# Altium schematic sheet 011_SoCSDMMC&I2C&I2S&GPIO.SchDoc(011_SoCSDMMC&I2C&I2S&GPIO)
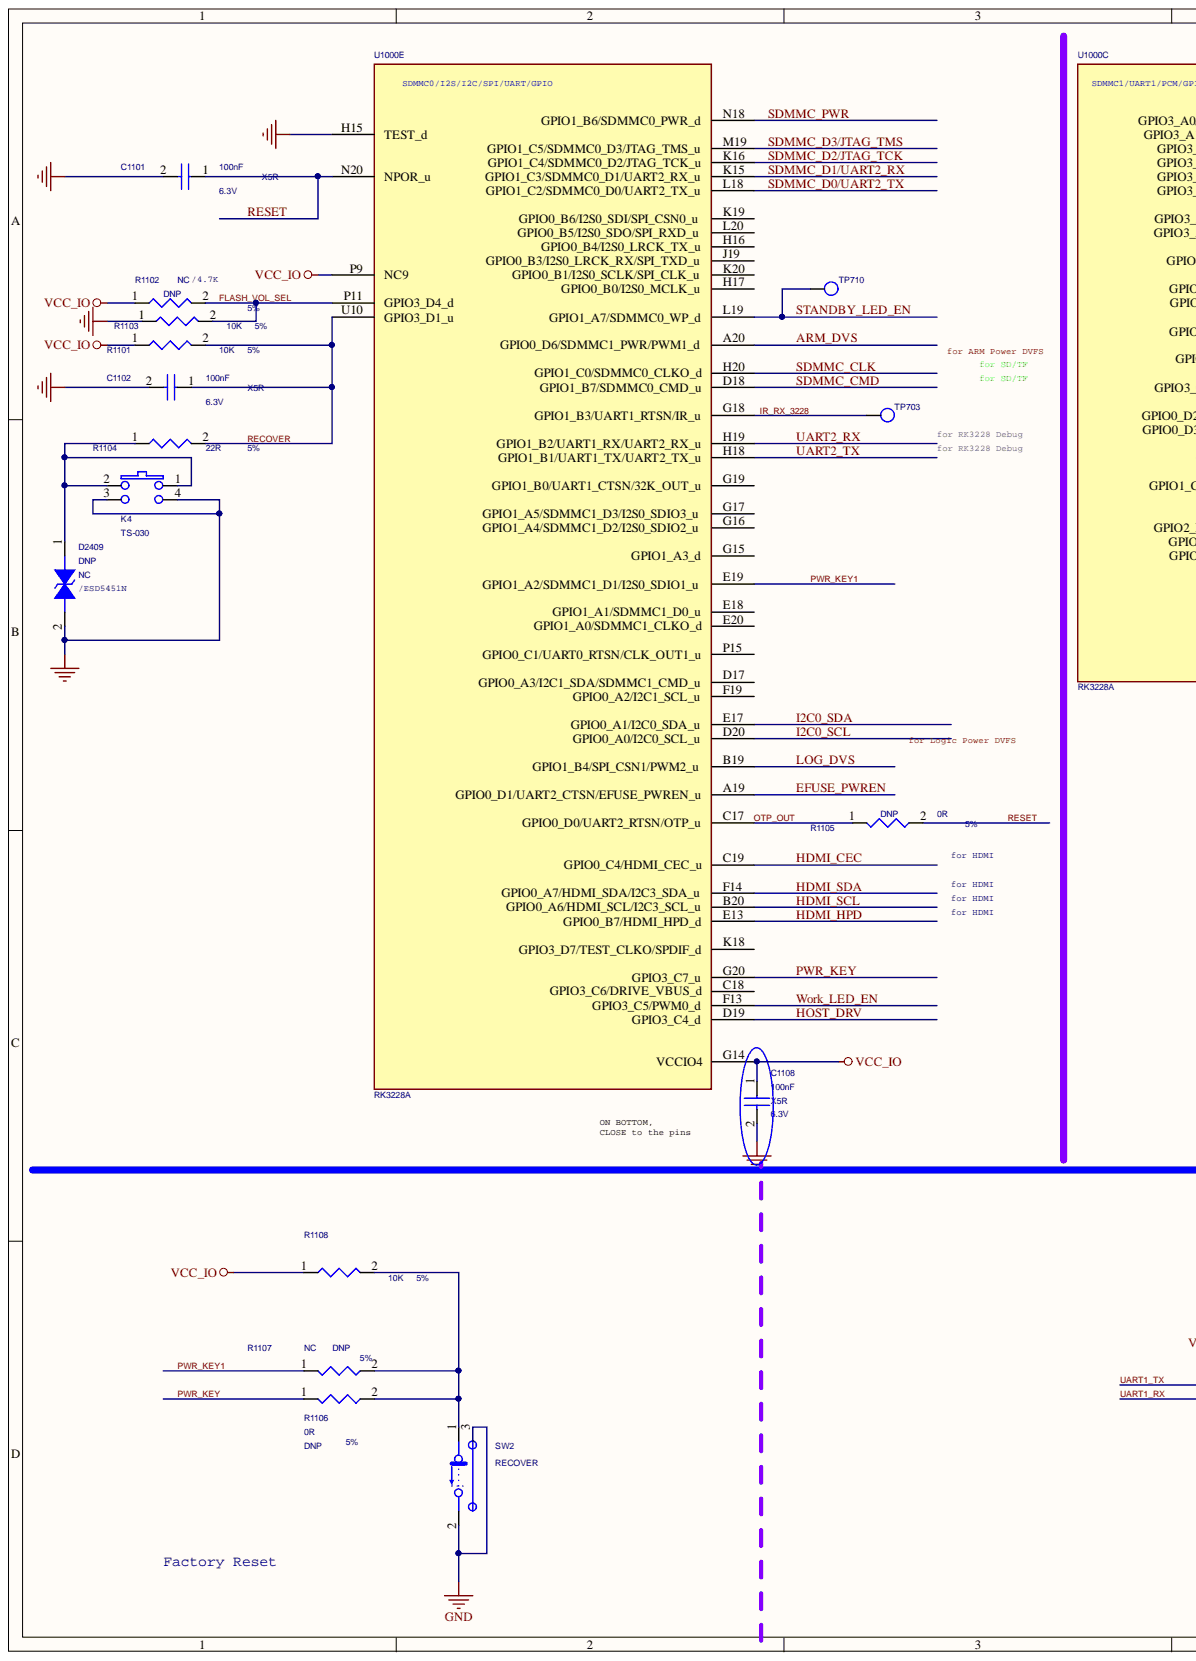
[diagram p0: 011_SoCSDMMC&I2C&I2S&GPIO.SchDoc, left]
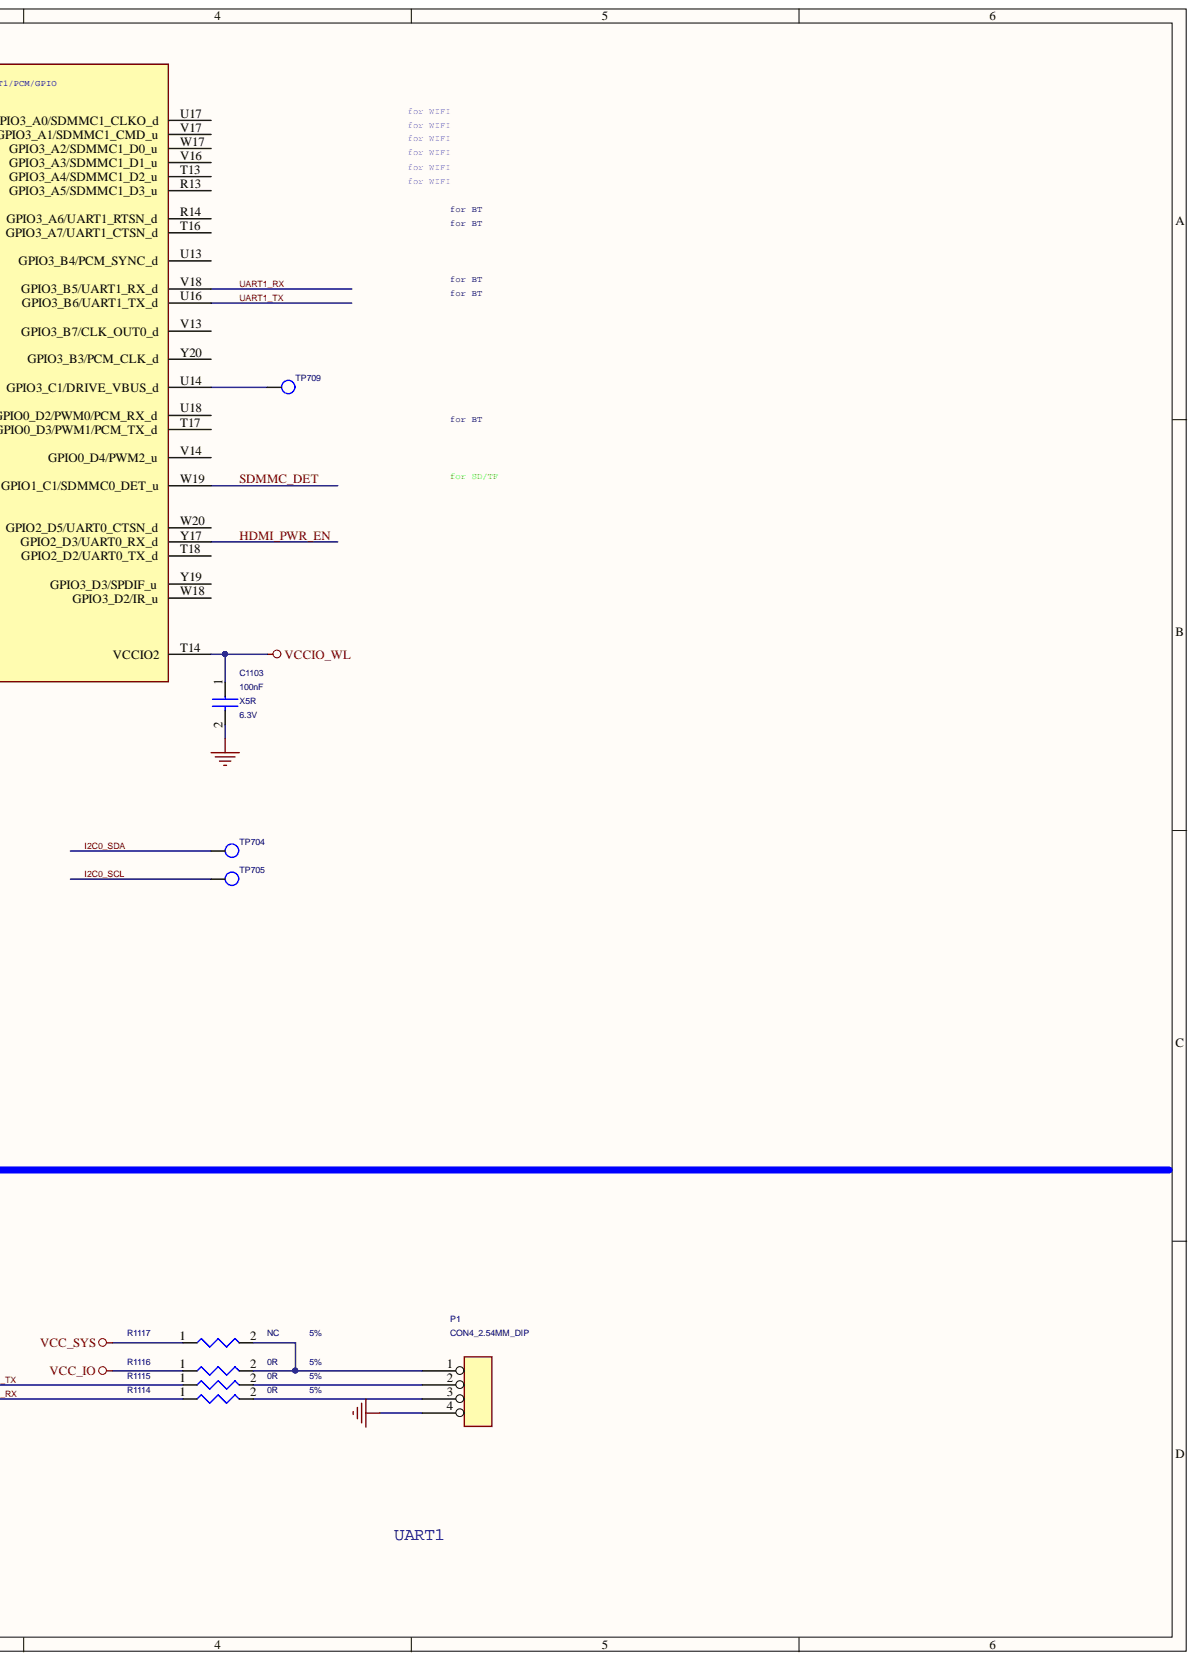
[diagram p1: 011_SoCSDMMC&I2C&I2S&GPIO.SchDoc, right]

## Parts
C1101  100nF  Voltage: 6.3V  [C0402]
C1102  100nF  Voltage: 6.3V  [C0402]
C1103  100nF  Voltage: 6.3V  Dielectric: X5R  [C0402]
C1108  100nF  Voltage: 6.3V  Dielectric: X5R  [C0402]
D2409  NC  OPTION: DNP  [ESD0402]
K4  TS-030  [SW-KFC-A04]
P1  CON4_2.54MM_DIP  [CON4_2.5MM_DIP]
R1101  10K  [R0402]
R1102  NC  option: DNP  [R0402]
R1103  10K  Tolerance: 5%  [R0402]
R1104  22R  [R0402]
R1105  0R  Option: DNP  [R0402]
R1106  0R  Tolerance: 5%  [R0402]
R1107  NC  Tolerance: 5%  Option: DNP  [R0402]
R1108  10K  [R0402]
R1114  0R  [R0402]
R1115  0R  [R0402]
R1116  0R  [R0402]
R1117  NC  [R0402]
SW2  RECOVER  [button]
TP703  TP_0.7  [TEST]  (value not drawn)
TP704  TP_0.7  [TEST]  (value not drawn)
TP705  TP_0.7  [TEST]  (value not drawn)
TP709  TP_0.7  [TEST]  (value not drawn)
TP710  TP_0.7  [TEST]  (value not drawn)
U1000  RK3228A  [BGA316-14X14_0.65]  (parts C,E)

## Nets
GND: C1101.2 C1102.2 C1103.2 C1108.2 D2409.2 K4.3 K4.4 P1.4 R1103.1 SW2.2 SW2.3 U1000.H15(TEST_d)
VCC_IO: C1108.1 R1101.1 R1102.1 R1108.1 R1116.1 U1000.G14(VCCIO4) U1000.P9(NC9)
VCC_SYS: R1117.1
VCCIO_WL: C1103.1 U1000.T14(VCCIO2)
ARM_DVS: U1000.A20(GPIO0_D6/SDMMC1_PWR/PWM1_d)
EFUSE_PWREN: U1000.A19(GPIO0_D1/UART2_CTSN/EFUSE_PWREN_u)
FLASH_VOL_SEL: R1102.2 R1103.2 U1000.P11(GPIO3_D4_d)
HDMI_CEC: U1000.C19(GPIO0_C4/HDMI_CEC_u)
HDMI_HPD: U1000.E13(GPIO0_B7/HDMI_HPD_d)
HDMI_PWR_EN: U1000.Y17(GPIO2_D3/UART0_RX_d)
HDMI_SCL: U1000.B20(GPIO0_A6/HDMI_SCL/I2C3_SCL_u)
HDMI_SDA: U1000.F14(GPIO0_A7/HDMI_SDA/I2C3_SDA_u)
HOST_DRV: U1000.D19(GPIO3_C4_d)
I2C0_SCL: TP705.1 U1000.D20(GPIO0_A0/I2C0_SCL_u)
I2C0_SDA: TP704.1 U1000.E17(GPIO0_A1/I2C0_SDA_u)
IR_RX_3228: TP703.1 U1000.G18(GPIO1_B3/UART1_RTSN/IR_u)
LOG_DVS: U1000.B19(GPIO1_B4/SPI_CSN1/PWM2_u)
OTP_OUT: R1105.1 U1000.C17(GPIO0_D0/UART2_RTSN/OTP_u)
PWR_KEY: R1106.1 U1000.G20(GPIO3_C7_u)
PWR_KEY1: R1107.1 U1000.E19(GPIO1_A2/SDMMC1_D1/I2S0_SDIO1_u)
RECOVER: C1102.1 R1101.2 R1104.2 U1000.U10(GPIO3_D1_u)
RESET: C1101.1 R1105.2 U1000.N20(NPOR_u)
SDMMC_CLK: U1000.H20(GPIO1_C0/SDMMC0_CLKO_d)
SDMMC_CMD: U1000.D18(GPIO1_B7/SDMMC0_CMD_u)
SDMMC_D0/UART2_TX: U1000.L18(GPIO1_C2/SDMMC0_D0/UART2_TX_u)
SDMMC_D1/UART2_RX: U1000.K15(GPIO1_C3/SDMMC0_D1/UART2_RX_u)
SDMMC_D2/JTAG_TCK: U1000.K16(GPIO1_C4/SDMMC0_D2/JTAG_TCK_u)
SDMMC_D3/JTAG_TMS: U1000.M19(GPIO1_C5/SDMMC0_D3/JTAG_TMS_u)
SDMMC_DET: U1000.W19(GPIO1_C1/SDMMC0_DET_u)
SDMMC_PWR: U1000.N18(GPIO1_B6/SDMMC0_PWR_d)
STANDBY_LED_EN: TP710.1 U1000.L19(GPIO1_A7/SDMMC0_WP_d)
UART1_RX: R1114.1 U1000.V18(GPIO3_B5/UART1_RX_d)
UART1_TX: R1115.1 U1000.U16(GPIO3_B6/UART1_TX_d)
UART2_RX: U1000.H19(GPIO1_B2/UART1_RX/UART2_RX_u)
UART2_TX: U1000.H18(GPIO1_B1/UART1_TX/UART2_TX_u)
Work_LED_EN: U1000.F13(GPIO3_C5/PWM0_d)
NetD2409_1: D2409.1 K4.1 K4.2 R1104.1
NetP1_1: P1.1 R1116.2 R1117.2
NetP1_2: P1.2 R1115.2
NetP1_3: P1.3 R1114.2
NetR1106_2: R1106.2 R1107.2 R1108.2 SW2.1
NetTP709_1: TP709.1 U1000.U14(GPIO3_C1/DRIVE_VBUS_d)

Not connected: U1000 C18(GPIO3_C6/DRIVE_VBUS_d) D17(GPIO0_A3/I2C1_SDA/SDMMC1_CMD_u) E18(GPIO1_A1/SDMMC1_D0_u) E20(GPIO1_A0/SDMMC1_CLKO_d) F19(GPIO0_A2/I2C1_SCL_u) G15(GPIO1_A3_d) G16(GPIO1_A4/SDMMC1_D2/I2S0_SDIO2_u) G17(GPIO1_A5/SDMMC1_D3/I2S0_SDIO3_u) G19(GPIO1_B0/UART1_CTSN/32K_OUT_u) H16(GPIO0_B4/I2S0_LRCK_TX_u) H17(GPIO0_B0/I2S0_MCLK_u) J19(GPIO0_B3/I2S0_LRCK_RX/SPI_TXD_u) K18(GPIO3_D7/TEST_CLKO/SPDIF_d) K19(GPIO0_B6/I2S0_SDI/SPI_CSN0_u) K20(GPIO0_B1/I2S0_SCLK/SPI_CLK_u) L20(GPIO0_B5/I2S0_SDO/SPI_RXD_u) P15(GPIO0_C1/UART0_RTSN/CLK_OUT1_u) R13(GPIO3_A5/SDMMC1_D3_u) R14(GPIO3_A6/UART1_RTSN_d) T13(GPIO3_A4/SDMMC1_D2_u) T16(GPIO3_A7/UART1_CTSN_d) T17(GPIO0_D3/PWM1/PCM_TX_d) T18(GPIO2_D2/UART0_TX_d) U13(GPIO3_B4/PCM_SYNC_d) U17(GPIO3_A0/SDMMC1_CLKO_d) U18(GPIO0_D2/PWM0/PCM_RX_d) V13(GPIO3_B7/CLK_OUT0_d) V14(GPIO0_D4/PWM2_u) V16(GPIO3_A3/SDMMC1_D1_u) V17(GPIO3_A1/SDMMC1_CMD_u) W17(GPIO3_A2/SDMMC1_D0_u) W18(GPIO3_D2/IR_u) W20(GPIO2_D5/UART0_CTSN_d) Y19(GPIO3_D3/SPDIF_u) Y20(GPIO3_B3/PCM_CLK_d)

## Library data of the parts
C1101-C1103 C1108 = CAP NP_Dup5: cap,100.00nF,+/-10%,6.3V,X5R,C0402; Manufacturer: TDK; Tolerance: 10%; RK PN: C1005X5R0J104K050BA; Priority: A; Number: 37; Part Type: C0402; Manufacturer PN: C1005X5R0J104K050BA; Component Kind: Standard; C_Voltage: 6.3V; Created by: JJJ
  C1101 C1102: Dielectric: X5R
D2409 = DIODE BI_DIR TRIG: 1-Line, Bi-directional, Transient Voltage Suppressors; Manufacturer: WillSemi(甲먀군랄); RK PN: ESD5451N; Priority: A; Part Type: ESD_TVS; Manufacturer PN: ESD5451N; Component Kind: Standard; Created by: JJJ
K4 = SW_TACT_SPST_SM_0: 싸뎌갹쟁TS-026-3; Description #2: 싸뎌갹쟁TS_013넌됫릅襁坮; Description #1: 싸뎌갹쟁TS_013넌됫릅襁坮; Component Kind: Standard
P1 = CON4_2.5MM_DIP_Dup1: option: DNP; Component Kind: Standard
R1101 R1108 = RESISTOR_Dup1: 哥棨슌훡롯量,10K,+/-5%,R0402,1/16W.; Manufacturer: YAGEO; Wattage: 1/16W; Tolerance: 5%; RK PN: RC0402JR-0710KL; Priority: A; Part Type: 哥棨슌훡롯量; Manufacturer PN: RC0402JR-0710KL; Component Kind: Standard; Created by: YTL
R1102 R1114-R1117 = RESISTOR_Dup1: 哥棨슌훡롯量,4K7,+/-5%,R0402,1/16W.; Manufacturer: YAGEO; Wattage: 1/16W; Tolerance: 5%; RK PN: RC0402JR-074K7L; Priority: A; Part Type: 哥棨슌훡롯量; Manufacturer PN: RC0402JR-074K7L; Component Kind: Standard; Created by: YTL
R1103 = RESISTOR_Dup1: 哥棨슌훡롯量,10K,+/-5%,R0402,1/16W.; Manufacturer: YAGEO; Wattage: 1/16W; RK PN: RC0402JR-0710KL; Priority: A; Part Type: 哥棨슌훡롯量; Manufacturer PN: RC0402JR-0710KL; Component Kind: Standard; Created by: YTL
R1104 = RESISTOR_Dup1: 哥棨슌훡롯量,22R,+/-5%,R0402,1/16W.; Manufacturer: YAGEO; Wattage: 1/16W; Tolerance: 5%; RK PN: RC0402JR-0722RL; Priority: A; Part Type: 哥棨슌훡롯量; Manufacturer PN: RC0402JR-0722RL; Component Kind: Standard; Created by: YTL
R1105 = RESISTOR_Dup1: 哥棨슌훡롯量,0R,+/-5%,R0402,1/16W.; Manufacturer: YAGEO; Wattage: 1/16W; Tolerance: 5%; RK PN: RC0402JR-070RL; Priority: A; Part Type: 哥棨슌훡롯量; Manufacturer PN: RC0402JR-070RL; Component Kind: Standard; Created by: YTL
R1106 = RESISTOR_Dup1: 哥棨슌훡롯量,0R,+/-5%,R0402,1/16W.; Manufacturer: YAGEO; Wattage: 1/16W; RK PN: RC0402JR-070RL; Priority: A; Option: DNP; Part Type: 哥棨슌훡롯量; Manufacturer PN: RC0402JR-070RL; Component Kind: Standard; Created by: YTL
R1107 = RESISTOR_Dup1: 哥棨슌훡롯量,0R,+/-5%,R0402,1/16W.; Manufacturer: YAGEO; Wattage: 1/16W; RK PN: RC0402JR-070RL; Priority: A; Part Type: 哥棨슌훡롯量; Manufacturer PN: RC0402JR-070RL; Component Kind: Standard; Created by: YTL
SW2 = SW-TACT_0: Part Type: push button switch갹쟁; Part Number: Button; Component Kind: Standard
TP703-TP705 TP709 TP710 = TP: Test Point 0.7mm; RK PN: TESTPONIT_0R7; Priority: A; Part Type: TP; Created Date: 20140617; Component Kind: Standard; Created by: CZD
U1000 = DSP_RK3228: ROCKCHIP CPU; Manufacturer: Rockchip (쐉拱匣); RK PN: RK3228; Priority: A; Part Type: DSP; Manufacturer PN: RK3228; Component Kind: Standard; Created by: JJJ
